# Altium schematic sheet Thermostat-IO.SchDoc(Thermostat-IO)
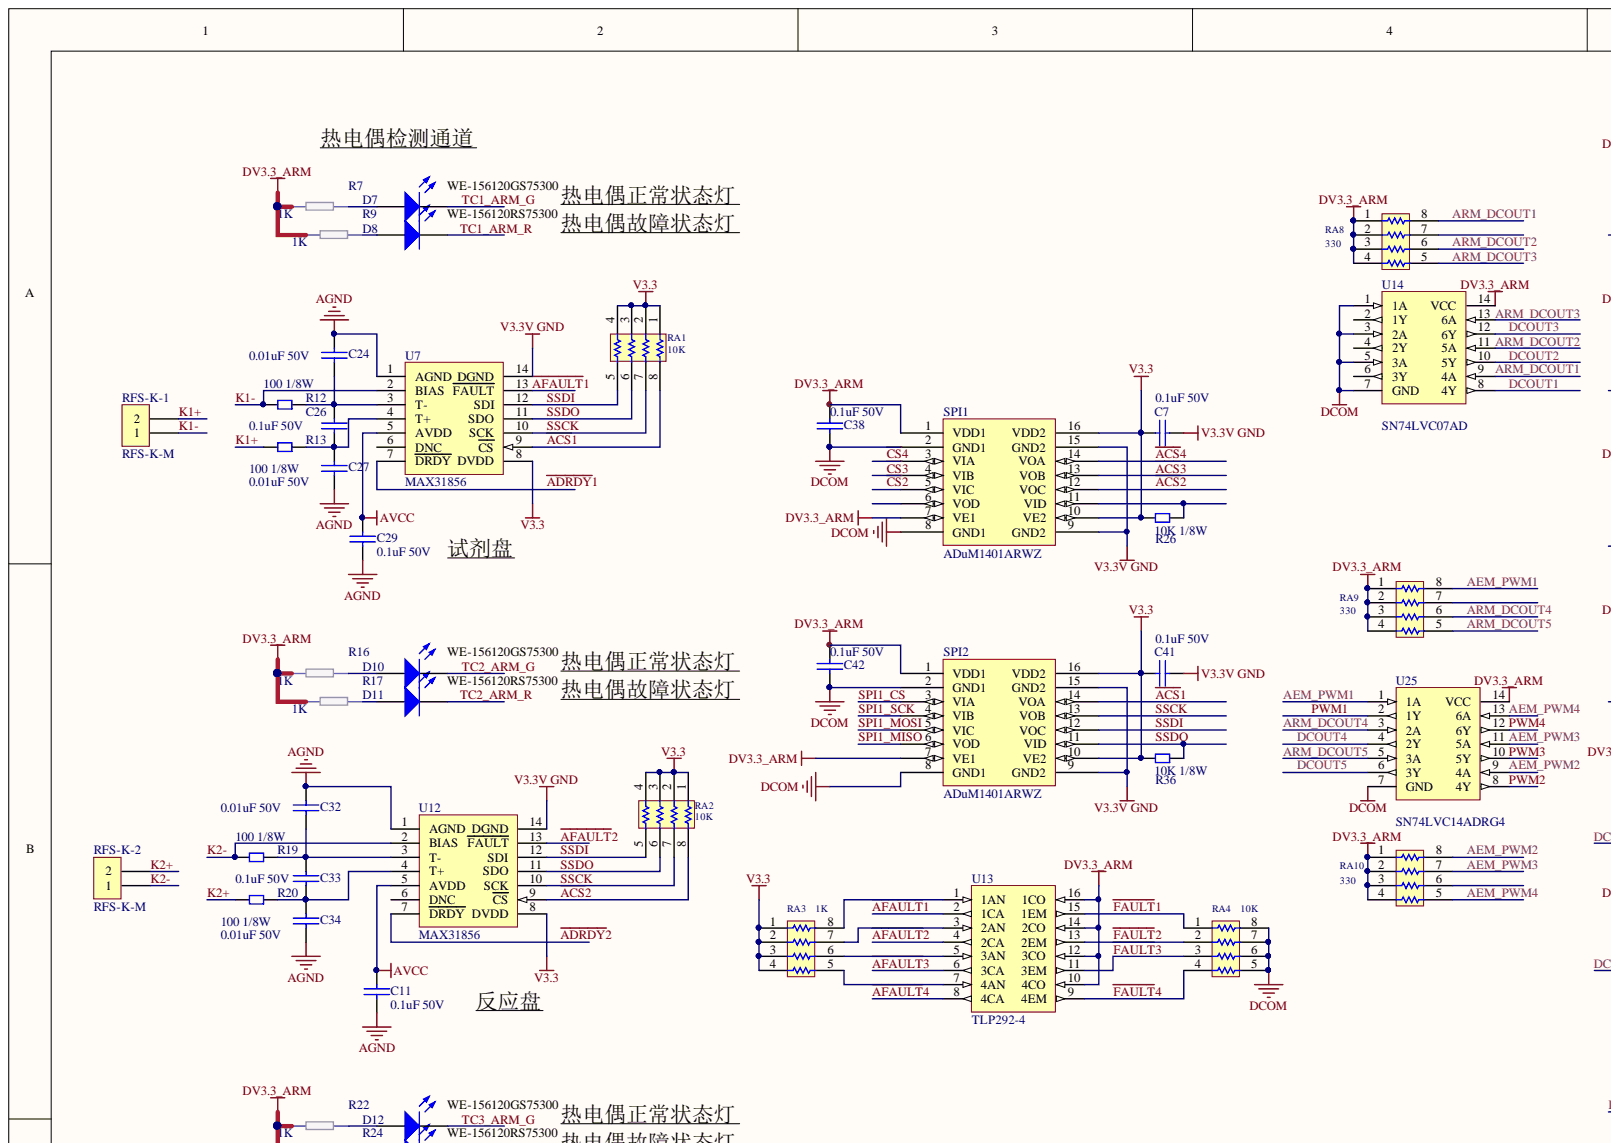
[diagram p0: Thermostat-IO.SchDoc, top left]
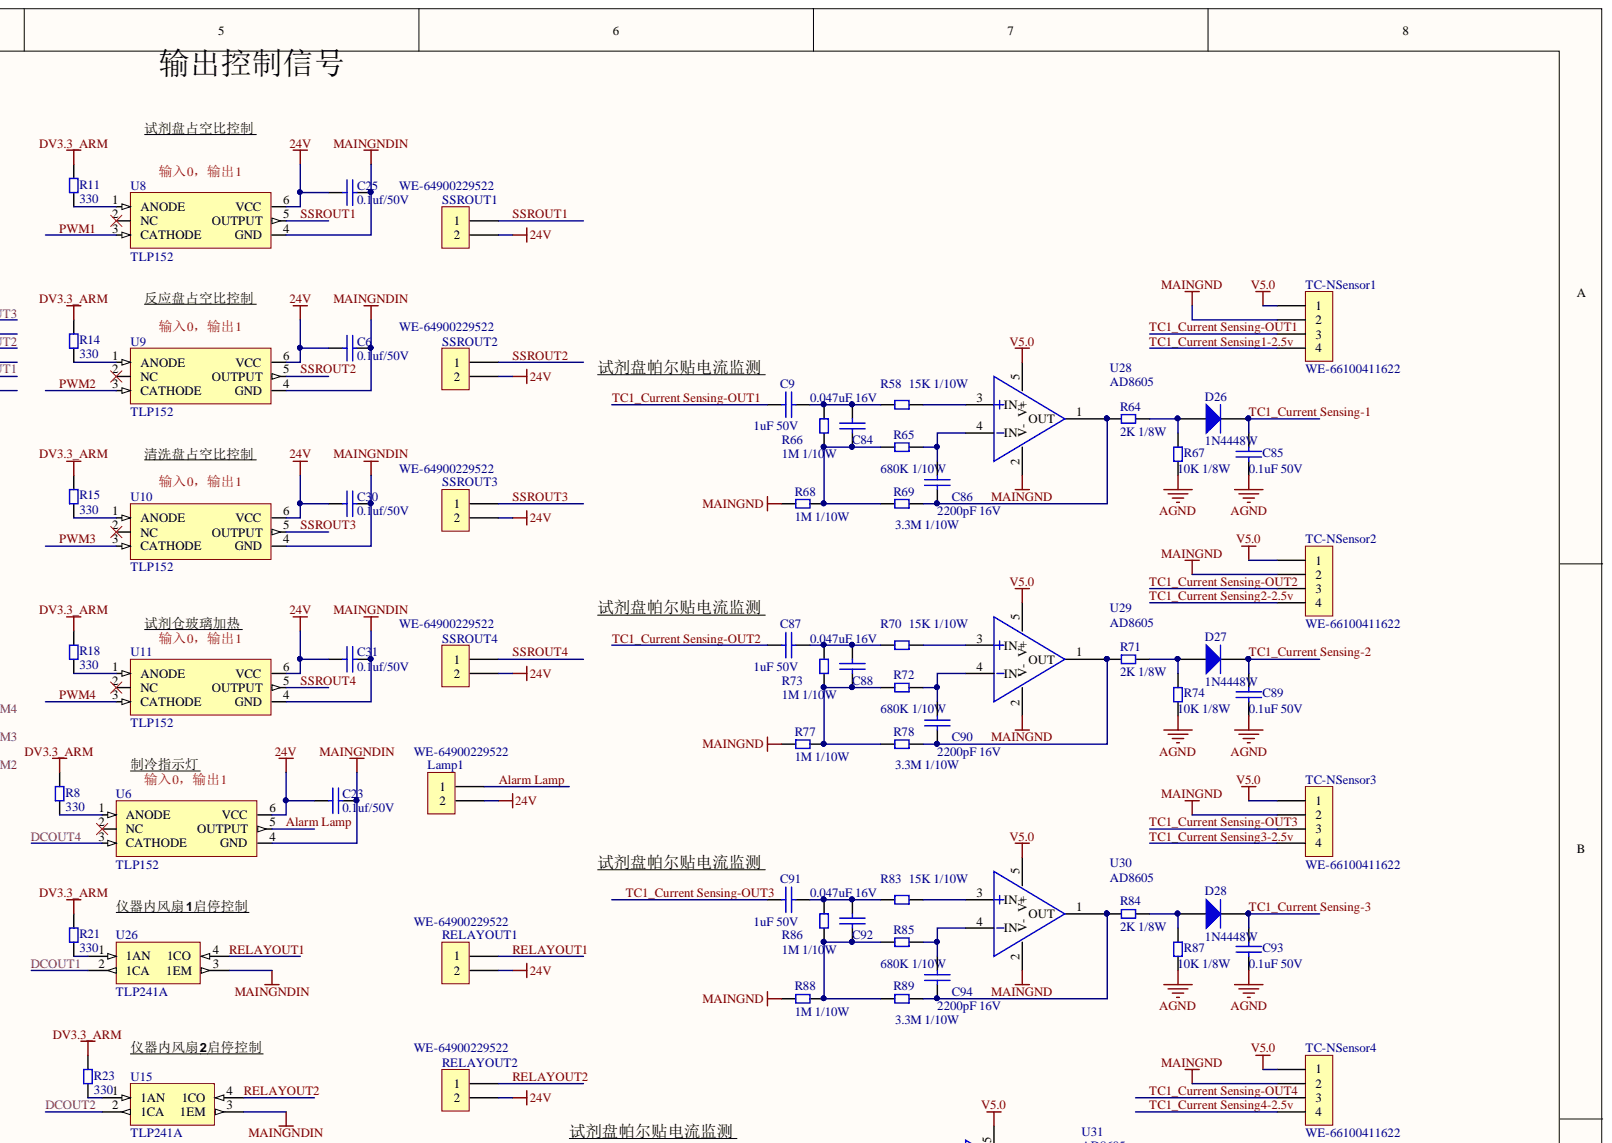
[diagram p1: Thermostat-IO.SchDoc, top right]
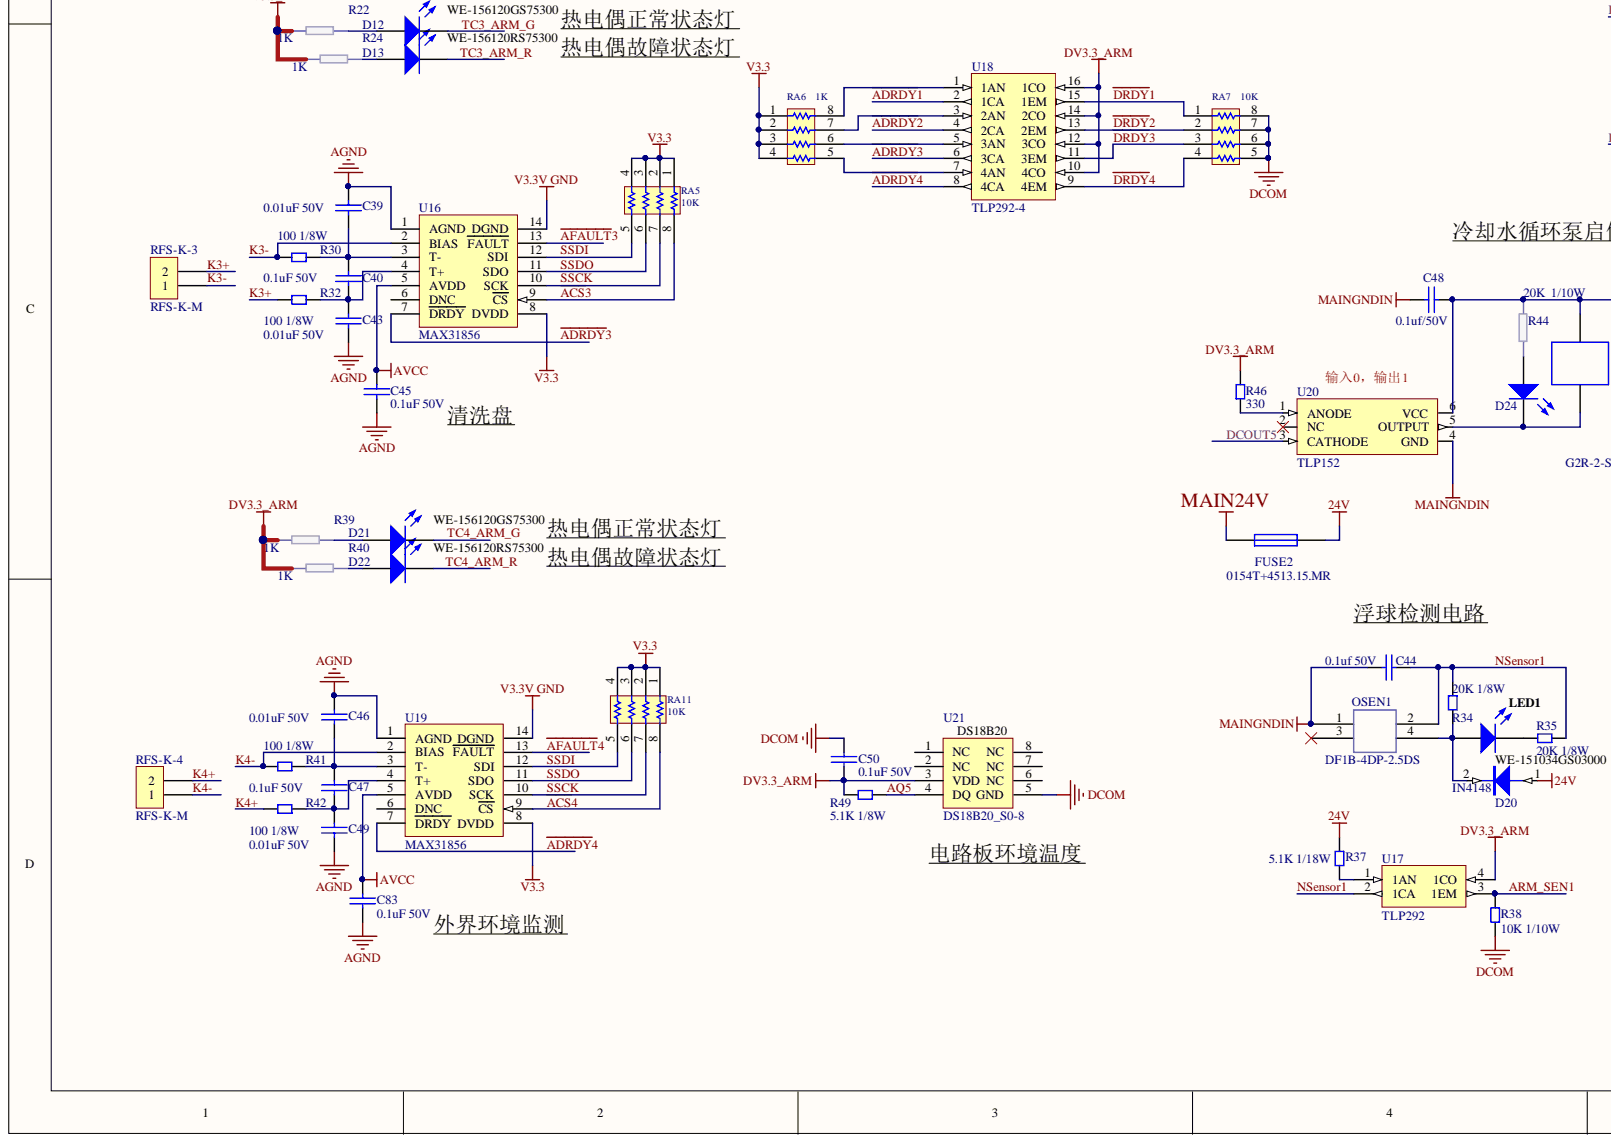
[diagram p2: Thermostat-IO.SchDoc, bottom left]
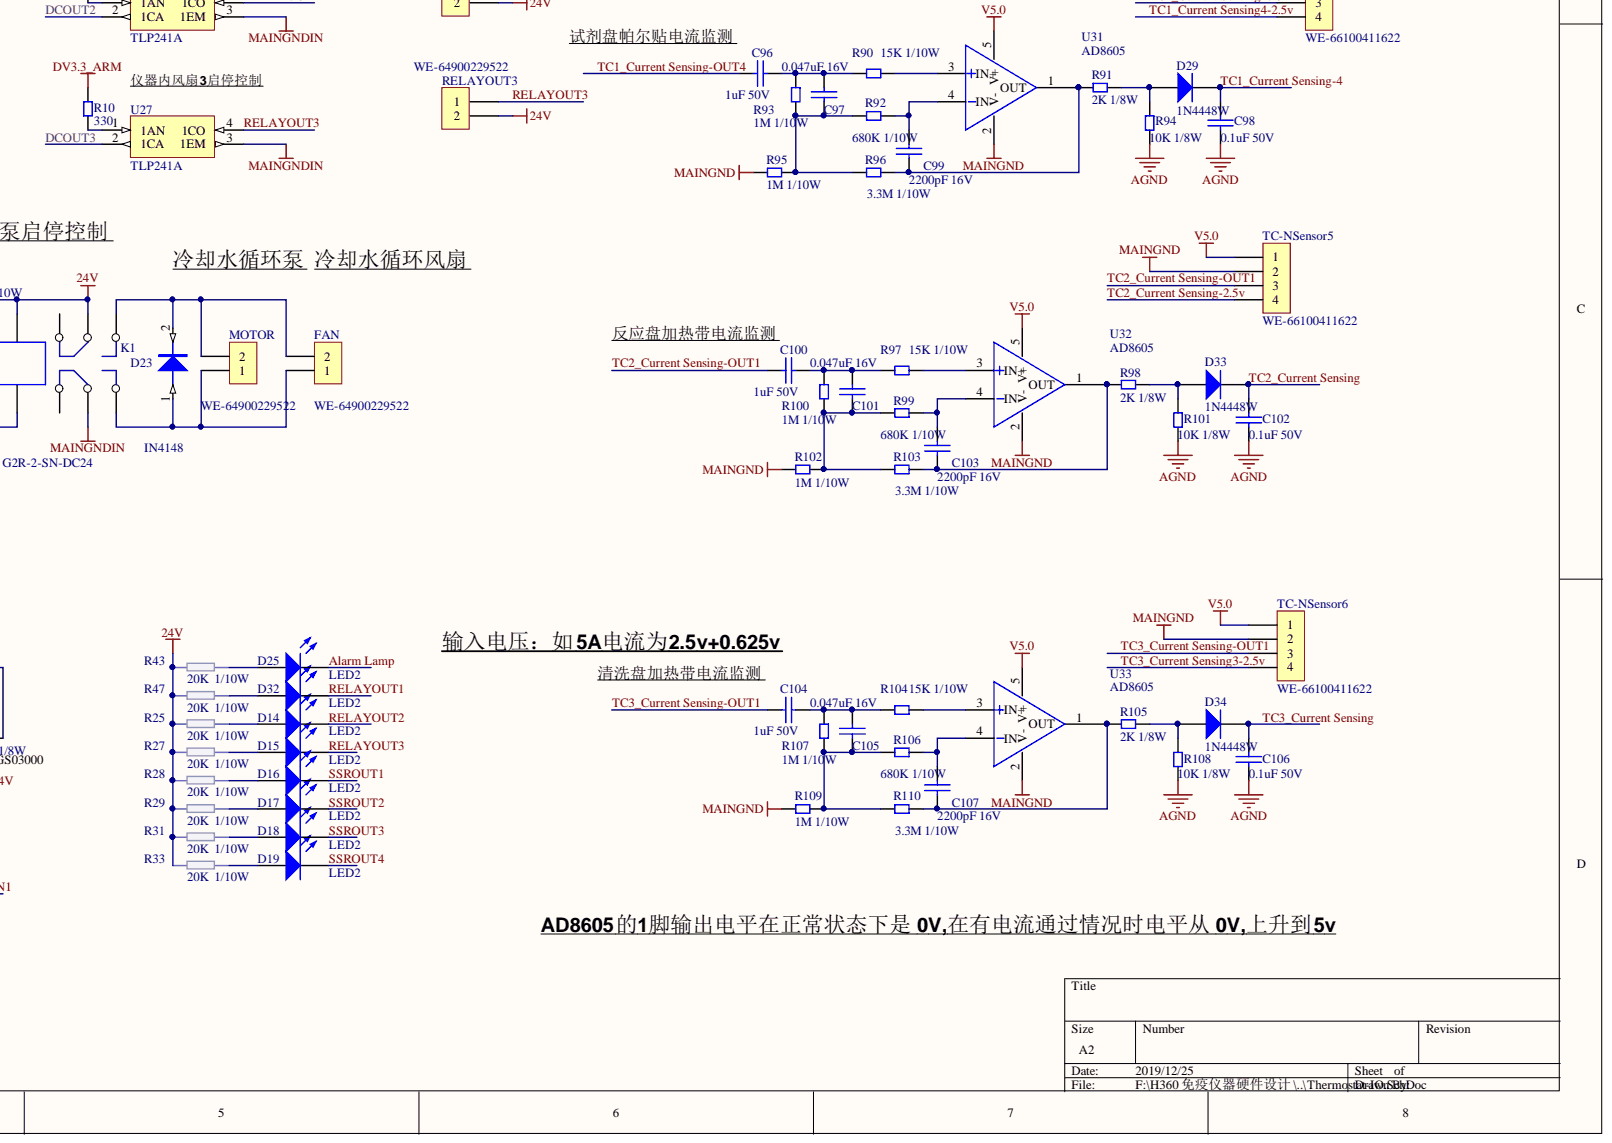
[diagram p3: Thermostat-IO.SchDoc, bottom right]

## Parts
C6  0.1uf/50V  [C0805_104/50V]
C7  0.1uF 50V  [C0603_104/50V]
C9  1uF 50V  [C0603_104/50V]
C11  0.1uF 50V  [C0603_104/50V]
C23  0.1uf/50V  [C0805_104/50V]
C24  0.01uF 50V  [C0603_103/50V]
C25  0.1uf/50V  [C0805_104/50V]
C26  0.1uF 50V  [C0603_104/50V]
C27  0.01uF 50V  [C0603_103/50V]
C29  0.1uF 50V  [C0603_104/50V]
C30  0.1uf/50V  [C0805_104/50V]
C31  0.1uf/50V  [C0805_104/50V]
C32  0.01uF 50V  [C0603_103/50V]
C33  0.1uF 50V  [C0603_104/50V]
C34  0.01uF 50V  [C0603_103/50V]
C38  0.1uF 50V  [C0603_104/50V]
C39  0.01uF 50V  [C0603_103/50V]
C40  0.1uF 50V  [C0603_104/50V]
C41  0.1uF 50V  [C0603_104/50V]
C42  0.1uF 50V  [C0603_104/50V]
C43  0.01uF 50V  [C0603_103/50V]
C44  0.1uf 50V  [C0805_104/50V]
C45  0.1uF 50V  [C0603_104/50V]
C46  0.01uF 50V  [C0603_103/50V]
C47  0.1uF 50V  [C0603_104/50V]
C48  0.1uf/50V  [C0805_104/50V]
C49  0.01uF 50V  [C0603_103/50V]
C50  0.1uF 50V  [C0603_104/50V]
C83  0.1uF 50V  [C0603_104/50V]
C84  0.047uF 16V  [C0603]
C85  0.1uF 50V  [C0603_104/50V]
C86  2200pF 16V  [C0603_222/50V]
C87  1uF 50V  [C0603_104/50V]
C88  0.047uF 16V  [C0603]
C89  0.1uF 50V  [C0603_104/50V]
C90  2200pF 16V  [C0603_222/50V]
C91  1uF 50V  [C0603_104/50V]
C92  0.047uF 16V  [C0603]
C93  0.1uF 50V  [C0603_104/50V]
C94  2200pF 16V  [C0603_222/50V]
C96  1uF 50V  [C0603_104/50V]
C97  0.047uF 16V  [C0603]
C98  0.1uF 50V  [C0603_104/50V]
C99  2200pF 16V  [C0603_222/50V]
C100  1uF 50V  [C0603_104/50V]
C101  0.047uF 16V  [C0603]
C102  0.1uF 50V  [C0603_104/50V]
C103  2200pF 16V  [C0603_222/50V]
C104  1uF 50V  [C0603_104/50V]
C105  0.047uF 16V  [C0603]
C106  0.1uF 50V  [C0603_104/50V]
C107  2200pF 16V  [C0603_222/50V]
D7  WE-156120GS75300  [LED_3MM]
D8  WE-156120RS75300  [LED_3MM]
D10  WE-156120GS75300  [LED_3MM]
D11  WE-156120RS75300  [LED_3MM]
D12  WE-156120GS75300  [LED_3MM]
D13  WE-156120RS75300  [LED_3MM]
D14  LED2  [LED_0805]
D15  LED2  [LED_0805]
D16  LED2  [LED_0805]
D17  LED2  [LED_0805]
D18  LED2  [LED_0805]
D19  LED2  [LED_0805]
D20  IN4148  [SOD123_IN4148]
D21  WE-156120GS75300  [LED_3MM]
D22  WE-156120RS75300  [LED_3MM]
D23  IN4148  [SOD123_IN4148]
D24  LED2  [LED_0805]  (value not drawn)
D25  LED2  [LED_0805]
D26  1N4448W  [SOD123_IN4148]
D27  1N4448W  [SOD123_IN4148]
D28  1N4448W  [SOD123_IN4148]
D29  1N4448W  [SOD123_IN4148]
D32  LED2  [LED_0805]
D33  1N4448W  [SOD123_IN4148]
D34  1N4448W  [SOD123_IN4148]
FAN  WE-64900229522  [WR-MPC4-4.2MM-2PIN_64900229522]
FUSE2  0154T+4513.15.MR  [Littlefuse--154T]
K1  G2R-2-SN-DC24  [G2R-2-SN-DC24-Omron]
Lamp1  WE-64900229522  [WR-MPC4-4.2MM-2PIN_64900229522]
LED1  WE-151034GS03000  [LED_3MM]
MOTOR  WE-64900229522  [WR-MPC4-4.2MM-2PIN_64900229522]
OSEN1  DF1B-4DP-2.5DS  [DF1B-4DP-2.54DS]
R7  1K  [RES0603_102]
R8  330  [res0603_331]
R9  1K  [RES0603_102]
R10  330  [res0603_331]
R11  330  [res0603_331]
R12  100 1/8W  [RES0805_100]
R13  100 1/8W  [RES0805_100]
R14  330  [res0603_331]
R15  330  [res0603_331]
R16  1K  [RES0603_102]
R17  1K  [RES0603_102]
R18  330  [res0603_331]
R19  100 1/8W  [RES0805_100]
R20  100 1/8W  [RES0805_100]
R21  330  [res0603_331]
R22  1K  [RES0603_102]
R23  330  [res0603_331]
R24  1K  [RES0603_102]
R25  20K  1/10W  [RES0603_203]
R26  10K 1/8W  [res0603_103]
R27  20K  1/10W  [RES0603_203]
R28  20K  1/10W  [RES0603_203]
R29  20K  1/10W  [RES0603_203]
R30  100 1/8W  [RES0805_100]
R31  20K  1/10W  [RES0603_203]
R32  100 1/8W  [RES0805_100]
R33  20K  1/10W  [RES0603_203]
R34  20K 1/8W  [RES0805]
R35  20K 1/8W  [RES0805]
R36  10K 1/8W  [res0603_103]
R37  5.1K 1/18W  [RES0805]
R38  10K 1/10W  [RES0603]
R39  1K  [RES0603_102]
R40  1K  [RES0603_102]
R41  100 1/8W  [RES0805_100]
R42  100 1/8W  [RES0805_100]
R43  20K  1/10W  [RES0603_203]
R44  20K  1/10W  [RES0603_203]
R46  330  [res0603_331]
R47  20K  1/10W  [RES0603_203]
R49  5.1K 1/8W  [res0603_512]
R58  15K 1/10W  [RES0603]
R64  2K 1/8W  [RES0603]
R65  680K 1/10W  [RES0603]
R66  1M 1/10W  [RES0603]
R67  10K 1/8W  [RES0603]
R68  1M 1/10W  [RES0603]
R69  3.3M 1/10W  [RES0603]
R70  15K 1/10W  [RES0603]
R71  2K 1/8W  [RES0603]
R72  680K 1/10W  [RES0603]
R73  1M 1/10W  [RES0603]
R74  10K 1/8W  [RES0603]
R77  1M 1/10W  [RES0603]
R78  3.3M 1/10W  [RES0603]
R83  15K 1/10W  [RES0603]
R84  2K 1/8W  [RES0603]
R85  680K 1/10W  [RES0603]
R86  1M 1/10W  [RES0603]
R87  10K 1/8W  [RES0603]
R88  1M 1/10W  [RES0603]
R89  3.3M 1/10W  [RES0603]
R90  15K 1/10W  [RES0603]
R91  2K 1/8W  [RES0603]
R92  680K 1/10W  [RES0603]
R93  1M 1/10W  [RES0603]
R94  10K 1/8W  [RES0603]
R95  1M 1/10W  [RES0603]
R96  3.3M 1/10W  [RES0603]
R97  15K 1/10W  [RES0603]
R98  2K 1/8W  [RES0603]
R99  680K 1/10W  [RES0603]
R100  1M 1/10W  [RES0603]
R101  10K 1/8W  [RES0603]
R102  1M 1/10W  [RES0603]
R103  3.3M 1/10W  [RES0603]
R104  15K 1/10W  [RES0603]
R105  2K 1/8W  [RES0603]
R106  680K 1/10W  [RES0603]
R107  1M 1/10W  [RES0603]
R108  10K 1/8W  [RES0603]
R109  1M 1/10W  [RES0603]
R110  3.3M 1/10W  [RES0603]
RA1  10K  [ra_yc164_102]
RA2  10K  [ra_yc164_102]
RA3  1K  [ra_yc164_102]
RA4  10K  [ra_yc164_102]
RA5  10K  [ra_yc164_102]
RA6  1K  [ra_yc164_102]
RA7  10K  [ra_yc164_102]
RA8  330  [ra_yc164_331]
RA9  330  [ra_yc164_331]
RA10  330  [ra_yc164_331]
RA11  10K  [ra_yc164_102]
RELAYOUT1  WE-64900229522  [WR-MPC4-4.2MM-2PIN_64900229522]
RELAYOUT2  WE-64900229522  [WR-MPC4-4.2MM-2PIN_64900229522]
RELAYOUT3  WE-64900229522  [WR-MPC4-4.2MM-2PIN_64900229522]
RFS-K-1  RFS-K-M  [RFS-K-PCB]
RFS-K-2  RFS-K-M  [RFS-K-PCB]
RFS-K-3  RFS-K-M  [RFS-K-PCB]
RFS-K-4  RFS-K-M  [RFS-K-PCB]
SPI1  ADuM1401ARWZ  [SOW16]
SPI2  ADuM1401ARWZ  [SOW16]
SSROUT1  WE-64900229522  [WR-MPC4-4.2MM-2PIN_64900229522]
SSROUT2  WE-64900229522  [WR-MPC4-4.2MM-2PIN_64900229522]
SSROUT3  WE-64900229522  [WR-MPC4-4.2MM-2PIN_64900229522]
SSROUT4  WE-64900229522  [WR-MPC4-4.2MM-2PIN_64900229522]
TC-NSensor1  WE-66100411622  [TC1_SEN]
TC-NSensor2  WE-66100411622  [TC1_SEN]
TC-NSensor3  WE-66100411622  [TC1_SEN]
TC-NSensor4  WE-66100411622  [TC1_SEN]
TC-NSensor5  WE-66100411622  [TC1_SEN]
TC-NSensor6  WE-66100411622  [TC1_SEN]
U6  TLP152  [SO6_TLP152]
U7  MAX31856  [TSSOP-14]
U8  TLP152  [SO6_TLP152]
U9  TLP152  [SO6_TLP152]
U10  TLP152  [SO6_TLP152]
U11  TLP152  [SO6_TLP152]
U12  MAX31856  [TSSOP-14]
U13  TLP292-4  [SOP16_TLP292-4]
U14  SN74LVC07AD  [SOIC-14-SN74LVC07AD]
U15  TLP241A  [SO4_TLP3553ACTP1]
U16  MAX31856  [TSSOP-14]
U17  TLP292  [SOP-4]
U18  TLP292-4  [SOP16_TLP292-4]
U19  MAX31856  [TSSOP-14]
U20  TLP152  [SO6_TLP152]
U21  DS18B20_S0-8  [SO-8]
U25  SN74LVC14ADRG4  [SOIC-14-SN74LVC07AD]
U26  TLP241A  [SO4_TLP3553ACTP1]
U27  TLP241A  [SO4_TLP3553ACTP1]
U28  AD8605  [SOT-23(RT-5)_AD8605]
U29  AD8605  [SOT-23(RT-5)_AD8605]
U30  AD8605  [SOT-23(RT-5)_AD8605]
U31  AD8605  [SOT-23(RT-5)_AD8605]
U32  AD8605  [SOT-23(RT-5)_AD8605]
U33  AD8605  [SOT-23(RT-5)_AD8605]

## Nets
24V: C6.2 C23.2 C25.2 C30.2 C31.2 C48.2 D20.1 FUSE2.2 K1.6 K1.8 Lamp1.2 R25.2 R27.2 R28.2 R29.2 R31.2 R33.2 R37.1 R43.2 R44.1 R47.2 RELAYOUT1.2 RELAYOUT2.2 RELAYOUT3.2 SSROUT1.2 SSROUT2.2 SSROUT3.2 SSROUT4.2 U6.6(VCC) U8.6(VCC) U9.6(VCC) U10.6(VCC) U11.6(VCC) U20.6(VCC)
AGND: C11.2 C24.2 C27.1 C29.2 C32.2 C34.1 C39.2 C43.1 C45.2 C46.2 C49.1 C83.2 C85.2 C89.2 C93.2 C98.2 C102.2 C106.2 R67.2 R74.2 R87.2 R94.2 R101.2 R108.2 U7.1(AGND) U12.1(AGND) U16.1(AGND) U19.1(AGND)
AVCC: C11.1 C29.1 C45.1 C83.1 U7.5(AVDD) U12.5(AVDD) U16.5(AVDD) U19.5(AVDD)
DCOM: C38.2 C42.2 C50.1 R38.2 RA4.5 RA4.6 RA4.7 RA4.8 RA7.5 RA7.6 RA7.7 RA7.8 SPI1.2(GND1) SPI1.8(GND1) SPI2.2(GND1) SPI2.8(GND1) U14.1(1A) U14.3(2A) U14.5(3A) U14.7(GND) U21.5(GND) U25.7(GND)
DV3.3_ARM: C38.1 C42.1 C50.2 R7.1 R8.2 R9.1 R10.2 R11.2 R14.2 R15.2 R16.1 R17.1 R18.2 R21.2 R22.1 R23.2 R24.1 R39.1 R40.1 R46.2 R49.2 RA8.1 RA8.2 RA8.3 RA8.4 RA9.1 RA9.2 RA9.3 RA9.4 RA10.1 RA10.2 RA10.3 RA10.4 SPI1.1(VDD1) SPI1.7(VE1) SPI2.1(VDD1) SPI2.7(VE1) U13.10(4CO) U13.12(3CO) U13.14(2CO) U13.16(1CO) U14.14(VCC) U17.4(1CO) U18.10(4CO) U18.12(3CO) U18.14(2CO) U18.16(1CO) U21.3(VDD) U25.14(VCC)
MAIN24V: FUSE2.1
MAINGND: R68.1 R77.1 R88.1 R95.1 R102.1 R109.1 TC-NSensor1.2 TC-NSensor2.2 TC-NSensor3.2 TC-NSensor4.2 TC-NSensor5.2 TC-NSensor6.2 U28.2(V-) U29.2(V-) U30.2(V-) U31.2(V-) U32.2(V-) U33.2(V-)
MAINGNDIN: C6.1 C23.1 C25.1 C30.1 C31.1 C44.2 C48.1 K1.3 OSEN1.1 U6.4(GND) U8.4(GND) U9.4(GND) U10.4(GND) U11.4(GND) U15.3(1EM) U20.4(GND) U26.3(1EM) U27.3(1EM)
V3.3: C7.1 C41.1 R26.2 R36.2 RA1.1 RA1.2 RA1.3 RA1.4 RA2.1 RA2.2 RA2.3 RA2.4 RA3.1 RA3.2 RA3.3 RA3.4 RA5.1 RA5.2 RA5.3 RA5.4 RA6.1 RA6.2 RA6.3 RA6.4 RA11.1 RA11.2 RA11.3 RA11.4 SPI1.10(VE2) SPI1.16(VDD2) SPI2.10(VE2) SPI2.16(VDD2) U7.8(DVDD) U12.8(DVDD) U16.8(DVDD) U19.8(DVDD)
V3.3V GND: C7.2 C41.2 SPI1.9(GND2) SPI1.15(GND2) SPI2.9(GND2) SPI2.15(GND2) U7.14(DGND) U12.14(DGND) U16.14(DGND) U19.14(DGND)
V5.0: TC-NSensor1.1 TC-NSensor2.1 TC-NSensor3.1 TC-NSensor4.1 TC-NSensor5.1 TC-NSensor6.1 U28.5(V+) U29.5(V+) U30.5(V+) U31.5(V+) U32.5(V+) U33.5(V+)
~{ACS}1: RA1.8 SPI2.14(VOA) U7.9(CS)
~{ACS}2: RA2.8 SPI1.12(VOC) U12.9(CS)
~{ACS}3: RA5.8 SPI1.13(VOB) U16.9(CS)
~{ACS}4: RA11.8 SPI1.14(VOA) U19.9(CS)
~{ADRDY}1: U7.7(DRDY) U18.2(1CA)
~{ADRDY}2: U12.7(DRDY) U18.4(2CA)
~{ADRDY}3: U16.7(DRDY) U18.6(3CA)
~{ADRDY}4: U18.8(4CA) U19.7(DRDY)
~{AFAULT}1: U7.13(FAULT) U13.2(1CA)
~{AFAULT}2: U12.13(FAULT) U13.4(2CA)
~{AFAULT}3: U13.6(3CA) U16.13(FAULT)
~{AFAULT}4: U13.8(4CA) U19.13(FAULT)
~{CS}2: SPI1.5(VIC)
~{CS}3: SPI1.4(VIB)
~{CS}4: SPI1.3(VIA)
~{DRDY}1: RA7.1 U18.15(1EM)
~{DRDY}2: RA7.2 U18.13(2EM)
~{DRDY}3: RA7.3 U18.11(3EM)
~{DRDY}4: RA7.4 U18.9(4EM)
~{FAULT}1: RA4.1 U13.15(1EM)
~{FAULT}2: RA4.2 U13.13(2EM)
~{FAULT}3: RA4.3 U13.11(3EM)
~{FAULT}4: RA4.4 U13.9(4EM)
AEM_PWM1: RA9.8 U25.1(1A)
AEM_PWM2: RA10.8 U25.9(4A)
AEM_PWM3: RA10.7 U25.11(5A)
AEM_PWM4: RA10.5 U25.13(6A)
Alarm Lamp: D25.2 Lamp1.1 U6.5(OUTPUT)
AQ5: R49.1 U21.4(DQ)
ARM_DCOUT1: RA8.8 U14.9(4A)
ARM_DCOUT2: RA8.6 U14.11(5A)
ARM_DCOUT3: RA8.5 U14.13(6A)
ARM_DCOUT4: RA9.6 U25.3(2A)
ARM_DCOUT5: RA9.5 U25.5(3A)
ARM_SEN1: R38.1 U17.3(1EM)
DCOUT1: U14.8(4Y) U26.2(1CA)
DCOUT2: U14.10(5Y) U15.2(1CA)
DCOUT3: U14.12(6Y) U27.2(1CA)
DCOUT4: U6.3(CATHODE) U25.4(2Y)
DCOUT5: U20.3(CATHODE) U25.6(3Y)
K1+: R13.2 RFS-K-1.2
K1-: R12.2 RFS-K-1.1 U7.2(BIAS)
K2+: R20.2 RFS-K-2.2
K2-: R19.2 RFS-K-2.1 U12.2(BIAS)
K3+: R32.2 RFS-K-3.2
K3-: R30.2 RFS-K-3.1 U16.2(BIAS)
K4+: R42.2 RFS-K-4.2
K4-: R41.2 RFS-K-4.1 U19.2(BIAS)
NSensor1: C44.1 OSEN1.2 R34.1 R35.1 U17.2(1CA)
PWM1: U8.3(CATHODE) U25.2(1Y)
PWM2: U9.3(CATHODE) U25.8(4Y)
PWM3: U10.3(CATHODE) U25.10(5Y)
PWM4: U11.3(CATHODE) U25.12(6Y)
RELAYOUT1: D32.2 RELAYOUT1.1 U26.4(1CO)
RELAYOUT2: D14.2 RELAYOUT2.1 U15.4(1CO)
RELAYOUT3: D15.2 RELAYOUT3.1 U27.4(1CO)
SPI1_CS: SPI2.3(VIA)
SPI1_MISO: SPI2.6(VOD)
SPI1_MOSI: SPI2.5(VIC)
SPI1_SCK: SPI2.4(VIB)
SSCK: RA1.7 RA2.7 RA5.7 RA11.7 SPI2.13(VOB) U7.10(SCK) U12.10(SCK) U16.10(SCK) U19.10(SCK)
SSDI: RA1.5 RA2.5 RA5.5 RA11.5 SPI2.12(VOC) U7.12(SDI) U12.12(SDI) U16.12(SDI) U19.12(SDI)
SSDO: R36.1 RA1.6 RA2.6 RA5.6 RA11.6 SPI2.11(VID) U7.11(SDO) U12.11(SDO) U16.11(SDO) U19.11(SDO)
SSROUT1: D16.2 SSROUT1.1 U8.5(OUTPUT)
SSROUT2: D17.2 SSROUT2.1 U9.5(OUTPUT)
SSROUT3: D18.2 SSROUT3.1 U10.5(OUTPUT)
SSROUT4: D19.2 SSROUT4.1 U11.5(OUTPUT)
TC1_ARM_G: D7.2
TC1_ARM_R: D8.2
TC1_Current Sensing1-2.5v: TC-NSensor1.4
TC1_Current Sensing2-2.5v: TC-NSensor2.4
TC1_Current Sensing3-2.5v: TC-NSensor3.4
TC1_Current Sensing4-2.5v: TC-NSensor4.4
TC1_Current Sensing-1: C85.1 D26.2
TC1_Current Sensing-2: C89.1 D27.2
TC1_Current Sensing-3: C93.1 D28.2
TC1_Current Sensing-4: C98.1 D29.2
TC1_Current Sensing-OUT1: C9.1 TC-NSensor1.3
TC1_Current Sensing-OUT2: C87.1 TC-NSensor2.3
TC1_Current Sensing-OUT3: C91.1 TC-NSensor3.3
TC1_Current Sensing-OUT4: C96.1 TC-NSensor4.3
TC2_ARM_G: D10.2
TC2_ARM_R: D11.2
TC2_Current Sensing: C102.1 D33.2
TC2_Current Sensing-2.5v: TC-NSensor5.4
TC2_Current Sensing-OUT1: C100.1 TC-NSensor5.3
TC3_ARM_G: D12.2
TC3_ARM_R: D13.2
TC3_Current Sensing: C106.1 D34.2
TC3_Current Sensing3-2.5v: TC-NSensor6.4
TC3_Current Sensing-OUT1: C104.1 TC-NSensor6.3
TC4_ARM_G: D21.2
TC4_ARM_R: D22.2
NetC9_2: C9.2 C84.1 R58.2 R66.1
NetC24_1: C24.1 C26.2 R12.1 U7.3(T-)
NetC26_1: C26.1 C27.2 R13.1 U7.4(T+)
NetC32_1: C32.1 C33.1 R19.1 U12.3(T-)
NetC33_2: C33.2 C34.2 R20.1 U12.4(T+)
NetC39_1: C39.1 C40.1 R30.1 U16.3(T-)
NetC40_2: C40.2 C43.2 R32.1 U16.4(T+)
NetC46_1: C46.1 C47.1 R41.1 U19.3(T-)
NetC47_2: C47.2 C49.2 R42.1 U19.4(T+)
NetC84_2: C84.2 R65.2 R66.2 R68.2 R69.2
NetC86_1: C86.1 R65.1 U28.4(IN-)
NetC86_2: C86.2 R64.1 R69.1 U28.1(OUT)
NetC87_2: C87.2 C88.1 R70.2 R73.1
NetC88_2: C88.2 R72.2 R73.2 R77.2 R78.2
NetC90_1: C90.1 R72.1 U29.4(IN-)
NetC90_2: C90.2 R71.1 R78.1 U29.1(OUT)
NetC91_2: C91.2 C92.1 R83.2 R86.1
NetC92_2: C92.2 R85.2 R86.2 R88.2 R89.2
NetC94_1: C94.1 R85.1 U30.4(IN-)
NetC94_2: C94.2 R84.1 R89.1 U30.1(OUT)
NetC96_2: C96.2 C97.1 R90.2 R93.1
NetC97_2: C97.2 R92.2 R93.2 R95.2 R96.2
NetC99_1: C99.1 R92.1 U31.4(IN-)
NetC99_2: C99.2 R91.1 R96.1 U31.1(OUT)
NetC100_2: C100.2 C101.1 R97.2 R100.1
NetC101_2: C101.2 R99.2 R100.2 R102.2 R103.2
NetC103_1: C103.1 R99.1 U32.4(IN-)
NetC103_2: C103.2 R98.1 R103.1 U32.1(OUT)
NetC104_2: C104.2 C105.1 R104.2 R107.1
NetC105_2: C105.2 R106.2 R107.2 R109.2 R110.2
NetC107_1: C107.1 R106.1 U33.4(IN-)
NetC107_2: C107.2 R105.1 R110.1 U33.1(OUT)
NetD7_1: D7.1 R7.2
NetD8_1: D8.1 R9.2
NetD10_1: D10.1 R16.2
NetD11_1: D11.1 R17.2
NetD12_1: D12.1 R22.2
NetD13_1: D13.1 R24.2
NetD14_1: D14.1 R25.1
NetD15_1: D15.1 R27.1
NetD16_1: D16.1 R28.1
NetD17_1: D17.1 R29.1
NetD18_1: D18.1 R31.1
NetD19_1: D19.1 R33.1
NetD20_2: D20.2 LED1.1 OSEN1.4 R34.2
NetD21_1: D21.1 R39.2
NetD22_1: D22.1 R40.2
NetD23_1: D23.1 FAN.1 K1.4 MOTOR.1
NetD23_2: D23.2 FAN.2 K1.5 MOTOR.2
NetD24_1: D24.1 R44.2
NetD24_2: D24.2 K1.1 U20.5(OUTPUT)
NetD25_1: D25.1 R43.1
NetD26_1: D26.1 R64.2 R67.1
NetD27_1: D27.1 R71.2 R74.1
NetD28_1: D28.1 R84.2 R87.1
NetD29_1: D29.1 R91.2 R94.1
NetD32_1: D32.1 R47.1
NetD33_1: D33.1 R98.2 R101.1
NetD34_1: D34.1 R105.2 R108.1
NetLED1_2: LED1.2 R35.2
NetR8_1: R8.1 U6.1(ANODE)
NetR10_1: R10.1 U27.1(1AN)
NetR11_1: R11.1 U8.1(ANODE)
NetR14_1: R14.1 U9.1(ANODE)
NetR15_1: R15.1 U10.1(ANODE)
NetR18_1: R18.1 U11.1(ANODE)
NetR21_1: R21.1 U26.1(1AN)
NetR23_1: R23.1 U15.1(1AN)
NetR26_1: R26.1 SPI1.11(VID)
NetR37_2: R37.2 U17.1(1AN)
NetR46_1: R46.1 U20.1(ANODE)
NetR58_1: R58.1 U28.3(IN+)
NetR70_1: R70.1 U29.3(IN+)
NetR83_1: R83.1 U30.3(IN+)
NetR90_1: R90.1 U31.3(IN+)
NetR97_1: R97.1 U32.3(IN+)
NetR104_1: R104.1 U33.3(IN+)
NetRA3_5: RA3.5 U13.7(4AN)
NetRA3_6: RA3.6 U13.5(3AN)
NetRA3_7: RA3.7 U13.3(2AN)
NetRA3_8: RA3.8 U13.1(1AN)
NetRA6_5: RA6.5 U18.7(4AN)
NetRA6_6: RA6.6 U18.5(3AN)
NetRA6_7: RA6.7 U18.3(2AN)
NetRA6_8: RA6.8 U18.1(1AN)

Not connected: K1 2 7; OSEN1 3; RA8 7; RA9 7; RA10 6; SPI1 6(VOD); U6 2(NC); U7 6(DNC); U8 2(NC); U9 2(NC); U10 2(NC); U11 2(NC); U12 6(DNC); U14 2(1Y) 4(2Y) 6(3Y); U16 6(DNC); U19 6(DNC); U20 2(NC); U21 1(NC) 2(NC) 6(NC) 7(NC) 8(NC)

## Library data of the parts
C6 C9 C23 C25 C30 C31 C44 C48 C84 C86-C88 C90-C92 C94 C96 C97 C99-C101 C103-C105 C107 = CAP: SAMSUNG MUL Ceramiac  5%; Component Kind: Standard
  C44: Library Name: 2017.4.24MainctrlBoard.PcbLib
C7 C38 C41 C42 = CAP: Capacitor; Component Kind: Standard
C11 C24 C26 C27 C29 C32-C34 C39 C40 C43 C45-C47 C49 C50 C83 = CAP: SAMSUNG  MULTILAYER  CERAMIC 5%; Component Kind: Standard
C85 C89 C93 C98 C102 C106 = CAP: Yageo (Phycomp)/CC0805KRX7R7BB104; Component Kind: Standard
D7 D10 D12 D21 = LED2: WL-SMRW SMD Reverse mount mono-color cylindrical Green,; Published: 8-Jun-2000; PackageVersion: Feb-2002; PackageReference: 3.2X1.6X1.1; PackageDescription: SM LED; 2 Flat Leads; Library Name: Miscellaneous Devices.IntLib; LatestRevisionNote: Re-released for DXP Platform.; LatestRevisionDate: 17-Jul-2002; Component Kind: Standard; Publisher: Altium Limited
D8 D11 D13 D22 = LED2: WL-SMRW SMD Reverse mount mono-color cylindrical Red,; Published: 8-Jun-2000; PackageVersion: Feb-2002; PackageReference: 3.2X1.6X1.1; PackageDescription: SM LED; 2 Flat Leads; Library Name: Miscellaneous Devices.IntLib; LatestRevisionNote: Re-released for DXP Platform.; LatestRevisionDate: 17-Jul-2002; Component Kind: Standard; Publisher: Altium Limited
D14-D19 D25 D32 = LED2: Typical RED, GREEN, YELLOW, AMBER GaAs LED; Simulation: LED2; Published: 8-Jun-2000; PackageVersion: Feb-2002; PackageReference: 3.2X1.6X1.1; PackageDescription: SM LED; 2 Flat Leads; Library Name: Miscellaneous Devices.IntLib; LatestRevisionNote: Re-released for DXP Platform.; LatestRevisionDate: 17-Jul-2002; Component Kind: Standard; Publisher: Altium Limited
D20 D23 = DIODE: 1N4148WS-7; Component Kind: Standard
D24 = LED2: Typical  GREEN,  GaAs LED; Simulation: LED2; Published: 8-Jun-2000; PackageVersion: Feb-2002; PackageReference: 3.2X1.6X1.1; PackageDescription: SM LED; 2 Flat Leads; Library Name: Miscellaneous Devices.IntLib; LatestRevisionNote: Re-released for DXP Platform.; LatestRevisionDate: 17-Jul-2002; Component Kind: Standard; Publisher: Altium Limited
D26-D29 D33 D34 = Diode: Default Diode; Simulation: DIODE; Signal Integrity: Diode; Published: 8-Jun-2000; PackageVersion: Sep-1996; PackageReference: SMC; PackageDescription: DO-214-AB/SMC; 2 C-Bend Leads; Body 7.9 x 5.9 mm, inc. leads (LxW); Library Name: Miscellaneous Devices.IntLib; LatestRevisionNote: Re-released for DXP Platform.; LatestRevisionDate: 17-Jul-2002; Component Kind: Standard; Code_JEDEC: DO-214-AB; Publisher: Altium Limited
FAN Lamp1 MOTOR RELAYOUT1-RELAYOUT3 SSROUT1-SSROUT4 = Header 2: WR-MPC4 Dual Row Male Right Angled Header; Library Name: DC out42_1.SCHLIB; Component Kind: Standard
FUSE2 = FUSE1: littelfuse  Surface Mount Fuses  154T; Component Kind: Standard
K1 = RELAY-DPDT: Library Name: 2015.10.1.SCHLIB; Component Kind: Standard
LED1 = D-153-0004: WL-TMRW LED, Green 3mm Waterclear without stopper; Peak Wave Length: 565 nm; Brightness: 12.5 mcd; Status: Production; Mounting Technology: Surface Mount; Library Name: AltiumDesignLibrary.DesignLib; Component Kind: Standard; Color: N/A; Category: LED
OSEN1 = CONN_2*2: HRS Double Row Type Socket(Cable Side) Right Through hole type DF1B-*DS-2.54RC; Library Name: Connector.SchLib; Component Kind: Standard
R7 R9 R16 R17 R22 R24 R25 R27-R29 R31 R33 R39 R40 R43 R44 R47 = Res2: YAGEO Chip Res 1/10W 1%; Simulation: RESISTOR; Signal Integrity: Res; Published: 8-Jun-2000; PackageReference: AXIAL-0.4; Library Name: 3DGeneral.SCHLIB; LatestRevisionNote: Re-released for DXP Platform.; LatestRevisionDate: 17-Jul-2002; Component Kind: Standard; Publisher: Altium Limited
R8 R11 R14 R15 R18 R46 = RES2: YAGEO Chip  1% 1/8W; Component Kind: Standard
R10 R12 R13 R19-R21 R23 R26 R30 R32 R36 R41 R42 R49 = RES2: Component Kind: Standard
R34 = RES2: YAGEO Chip  1%  1/8W; Component Kind: Standard
R35 = RES2: YAGEO Chip  1%; Component Kind: Standard
R37 = RES2: YAGEO Chip Res 1/8W; Component Kind: Standard
R38 R64 R67 R71 R74 R84 R87 R91 R94 R98 R101 R105 R108 = RES2: YAGEO Chip Res 1/10W; Component Kind: Standard
R58 R65 R66 R68-R70 R72 R73 R77 R78 R83 R85 R86 R88-R90 R92 R93 R95-R97 R99 R100 R102-R104 R106 R107 R109 R110 = RES2: YAGEO Chip Res 1/10W 1%; Component Kind: Standard
RA1-RA11 = MNR34: 3216x4 Resistor Array; AEMS Code: 1300330; Library Name: 2015.10.1.SCHLIB; Component Kind: Standard
RFS-K-1-RFS-K-4 = CON2: Description: RFS-K-M; Component Kind: Standard; Library Name: Thermostat_1.SCHLIB
TC-NSensor1-TC-NSensor6 = CON4: WR-WTB  intercom Single Row Male Vertical Shrouded Locking Header; Library Name: SCH library_20170331.SCHLIB; Component Kind: Standard
U6 U8-U11 U20 = TLP701: Photocouplers GaA-As Infrared LED and Photo IC; Library Name: H200-IO_Project.SCHLIB; Component Kind: Standard
U13 U18 = TLP291-4: TOSHIBA PHOTOCOUPLER GaAs IRED & PHOTO-TRANSISTOR; Library Name: 2015.10.1.SCHLIB; Component Kind: Standard
U14 U25 = 7414: HEX SCHMITT-TRIGGER INVERTERS; Library Name: 2015.10.1.SCHLIB; Component Kind: Standard
U15 U17 U26 U27 = TLP292: TOSHIBA PHOTOCOUPLER InGaAs IRED & PHOTO-TRANSISTOR; Library Name: H200 Slave machine.SCHLIB; Component Kind: Standard
SPI1 SPI2 = ADuM1401ARWZ: Component Kind: Standard
U7 U12 U16 U19 = MAX31856: Library Name: 2015.10.1.SCHLIB; Component Kind: Standard
U21 = DS18B20_S0-8: Library Name: 2015.10.1.SCHLIB; Component Kind: Standard
U28-U33 = AD8605: Component Kind: Standard; Library Name: Thermostat.SCHLIB
